# Altium schematic sheet main.SchDoc(main)
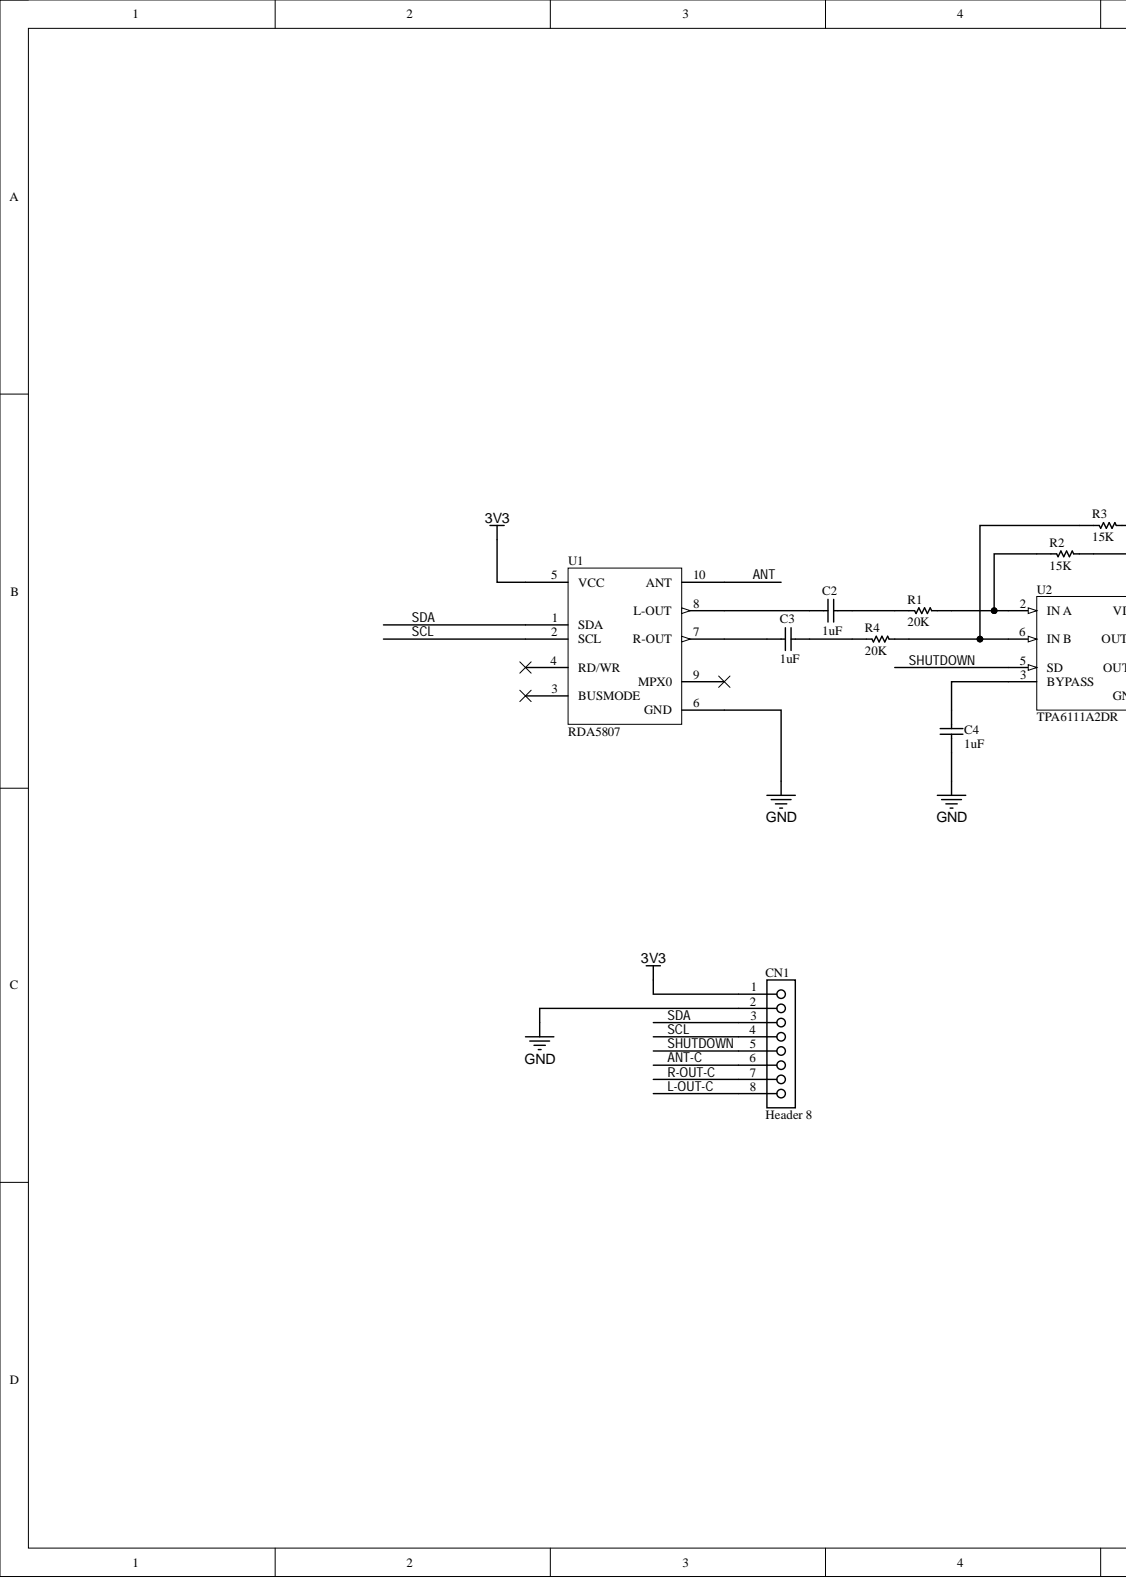
[diagram p0: main.SchDoc, left]
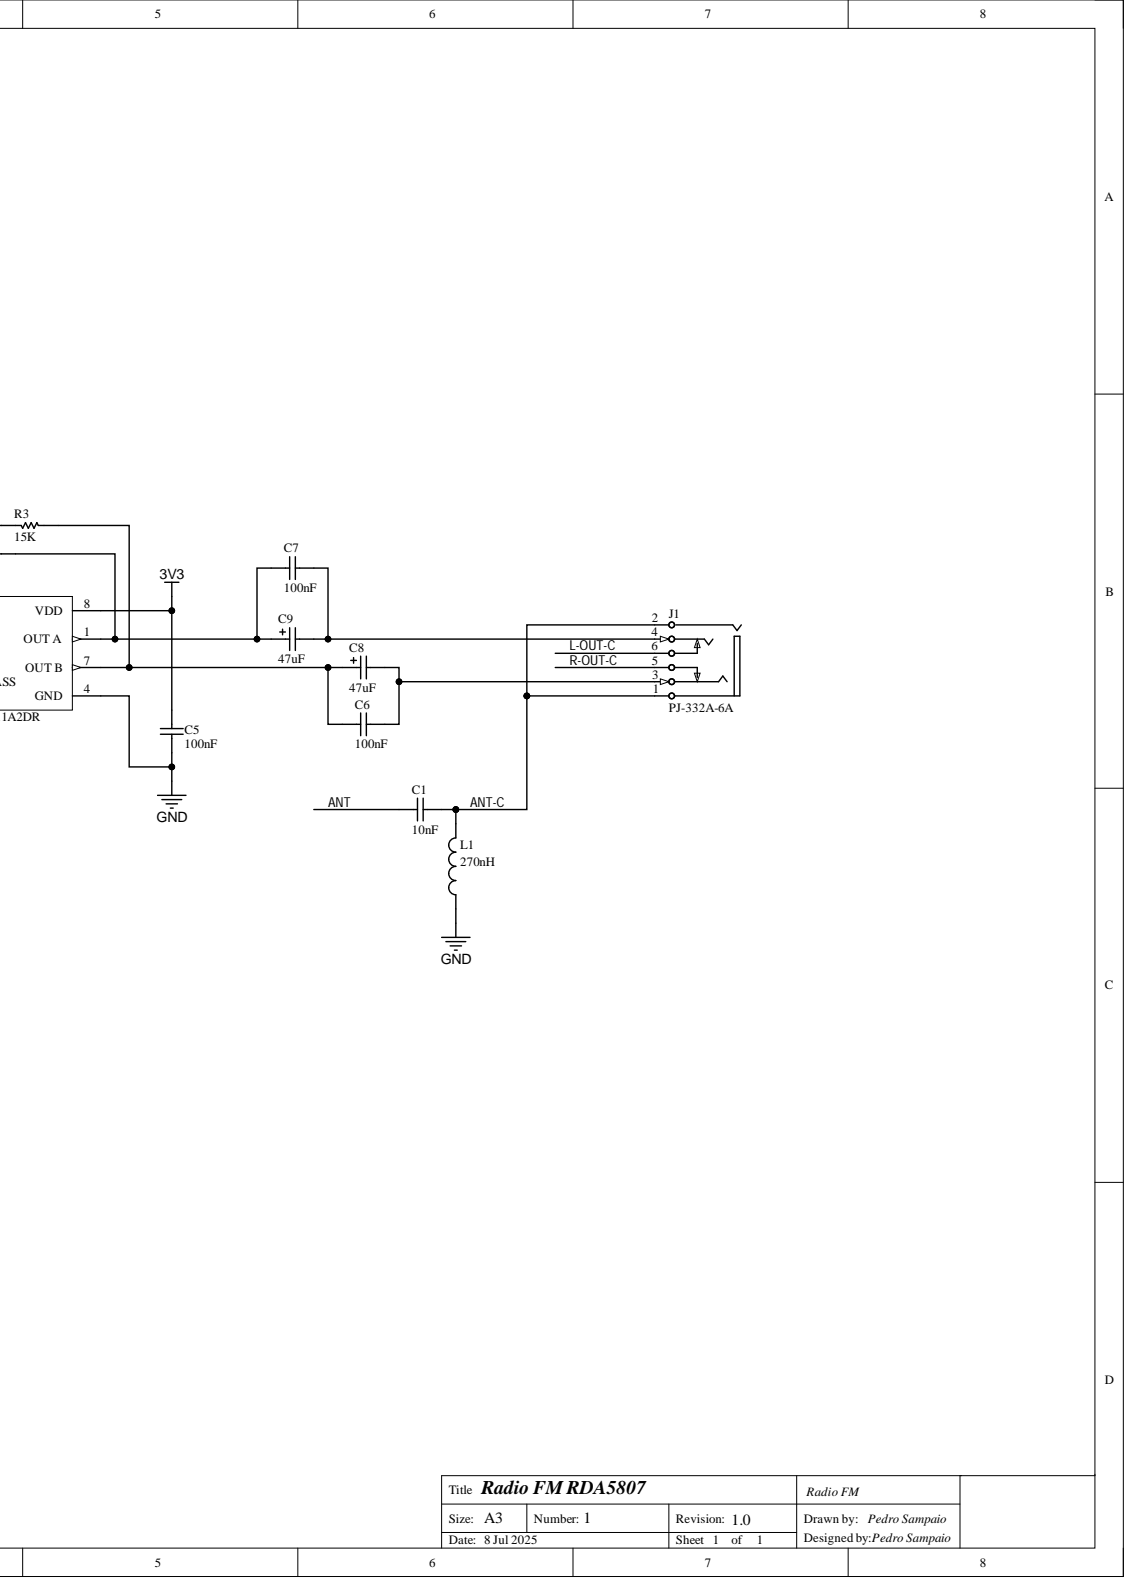
[diagram p1: main.SchDoc, right]

## Parts
C1  value 10nF  [CAP_0603_08]  (hidden comment: C0603)
C2  value 1uF  [CAP_0603_08]  (hidden comment: C0603)
C3  value 1uF  [CAP_0603_08]  (hidden comment: C0603)
C4  value 1uF  [CAP_0603_08]  (hidden comment: C0603)
C5  value 100nF  [CAP_0603_08]  (hidden comment: C0603)
C6  value 100nF  [CAP_0603_08]  (hidden comment: C0603)
C7  value 100nF  [CAP_0603_08]  (hidden comment: C0603)
C8  value 47uF  [CAP_CASE-A_3216]  (hidden comment: TAJA106K010RNJ)
C9  value 47uF  [CAP_CASE-A_3216]  (hidden comment: TAJA106K010RNJ)
CN1  Header 8  [HDR1X8]
J1  PJ-332A-6A  [AUDIO-SMD_PJ-332A-6A]
L1  value 270nH  [IND_0603]  (hidden comment: Inductor)
R1  value 20K  [RES_0805]  (hidden comment: Res Semi)
R2  value 15K  [RES_0805]  (hidden comment: Res Semi)
R3  value 15K  [RES_0805]  (hidden comment: Res Semi)
R4  value 20K  [RES_0805]  (hidden comment: Res Semi)
U1  RDA5807  [TEA5767-SOP10]
U2  TPA6111A2DR  [SOIC127P600X175-8N]

## Nets
3V3: C5.2 CN1.1 U1.5(VCC) U2.8(VDD)
GND: C4.1 C5.1 CN1.2 L1.1 U1.6(GND) U2.4(GND)
ANT: C1.2 U1.10(ANT)
ANT-C: C1.1 CN1.6 J1.1 J1.2 L1.2
L-OUT-C: CN1.8 J1.6
R-OUT-C: CN1.7 J1.5
SCL: CN1.4 U1.2(SCL)
SDA: CN1.3 U1.1(SDA)
SHUTDOWN: CN1.5 U2.5(SD)
NetC2_1: C2.1 R1.1
NetC2_2: C2.2 U1.8(L-OUT)
NetC3_1: C3.1 R4.1
NetC3_2: C3.2 U1.7(R-OUT)
NetC4_2: C4.2 U2.3(BYPASS)
NetC6_1: C6.1 C8.2 J1.3
NetC6_2: C6.2 C8.1 R3.2 U2.7(OUT_B)
NetC7_1: C7.1 C9.2 J1.4
NetC7_2: C7.2 C9.1 R2.2 U2.1(OUT_A)
NetR1_2: R1.2 R2.1 U2.2(IN_A)
NetR3_1: R3.1 R4.2 U2.6(IN_B)

Not connected: U1 3(BUSMODE) 4(RD/WR) 9(MPX0)

## Library data of the parts
C1-C7 = C0603: Ceramic Chip Capacitor - Standard; Component Kind: Standard; Library Name: PS-Library.IntLib; PackageReference: 0603; Signal Integrity: C0603; Simulation: CAP; Supplier 1: LCSC
  C1: LCSC Part #: C100042; Supplier Part Number 1: C100042
  C2 C3: LCSC Part #: C519559; Supplier Part Number 1: C519559
  C4: LCSC Part #: C1592; Supplier Part Number 1: C1592
  C5-C7: LCSC Part #: C1590; Supplier Part Number 1: C1590
C8 C9 = CAP T491A: Solid Tantalum Chip Capacitor, Standard T491 Series - Industrial Grade; Component Kind: Standard; LCSC Part #: C7177; Library Name: PS-Library.IntLib; PackageReference: CASE_A; Supplier 1: LCSC; Supplier Part Number 1: C7177
CN1 = Header 8: Type, 8, Pitch 2.54 mm; Component Kind: Standard; Library Name: PS-Library.IntLib; PackageReference: 0903278x865
J1 = PJ-332A-6A: Stereo Jack 2.5mm, Right Angle, Surface Mount, 9mm Wide; 6-Position; Non-thread; Component Kind: Standard; LCSC Part #: C2848643; Library Name: Schlib.SchLib; PackageReference: PJ-332A-6A; Signal Integrity: Audio Jack; Supplier 1: LCSC; Supplier Part Number 1: C2848643
R1-R4 = Res 0805: Semiconductor Resistor; Component Kind: Standard; Library Name: Schlib.SchLib; Supplier 1: LCSC
  R1 R4: LCSC Part #: C4328; Supplier Part Number 1: C4328
  R2 R3: LCSC Part #: C17475; Supplier Part Number 1: C17475
U1 = TEA5767: Component Kind: Standard; Description: RDA5807; Library Name: LibComponents.IntLib
U2 = LM4880M: Dual 200 mW Audio Power Amplifier with Shutdown Mode; Component Kind: Standard; LCSC Part #: C497472; Library Name: Schlib.SchLib; PackageReference: M08A; Supplier 1: LCSC; Supplier Part Number 1: C497472
L1 = Inductor: Component Kind: Standard; Description: Inductor; LCSC Part #: C703105; Library Name: PS-Library.IntLib; PackageReference: 0603; Supplier 1: LCSC; Supplier Part Number 1: C703105
